# Altium schematic sheet Power.SchDoc
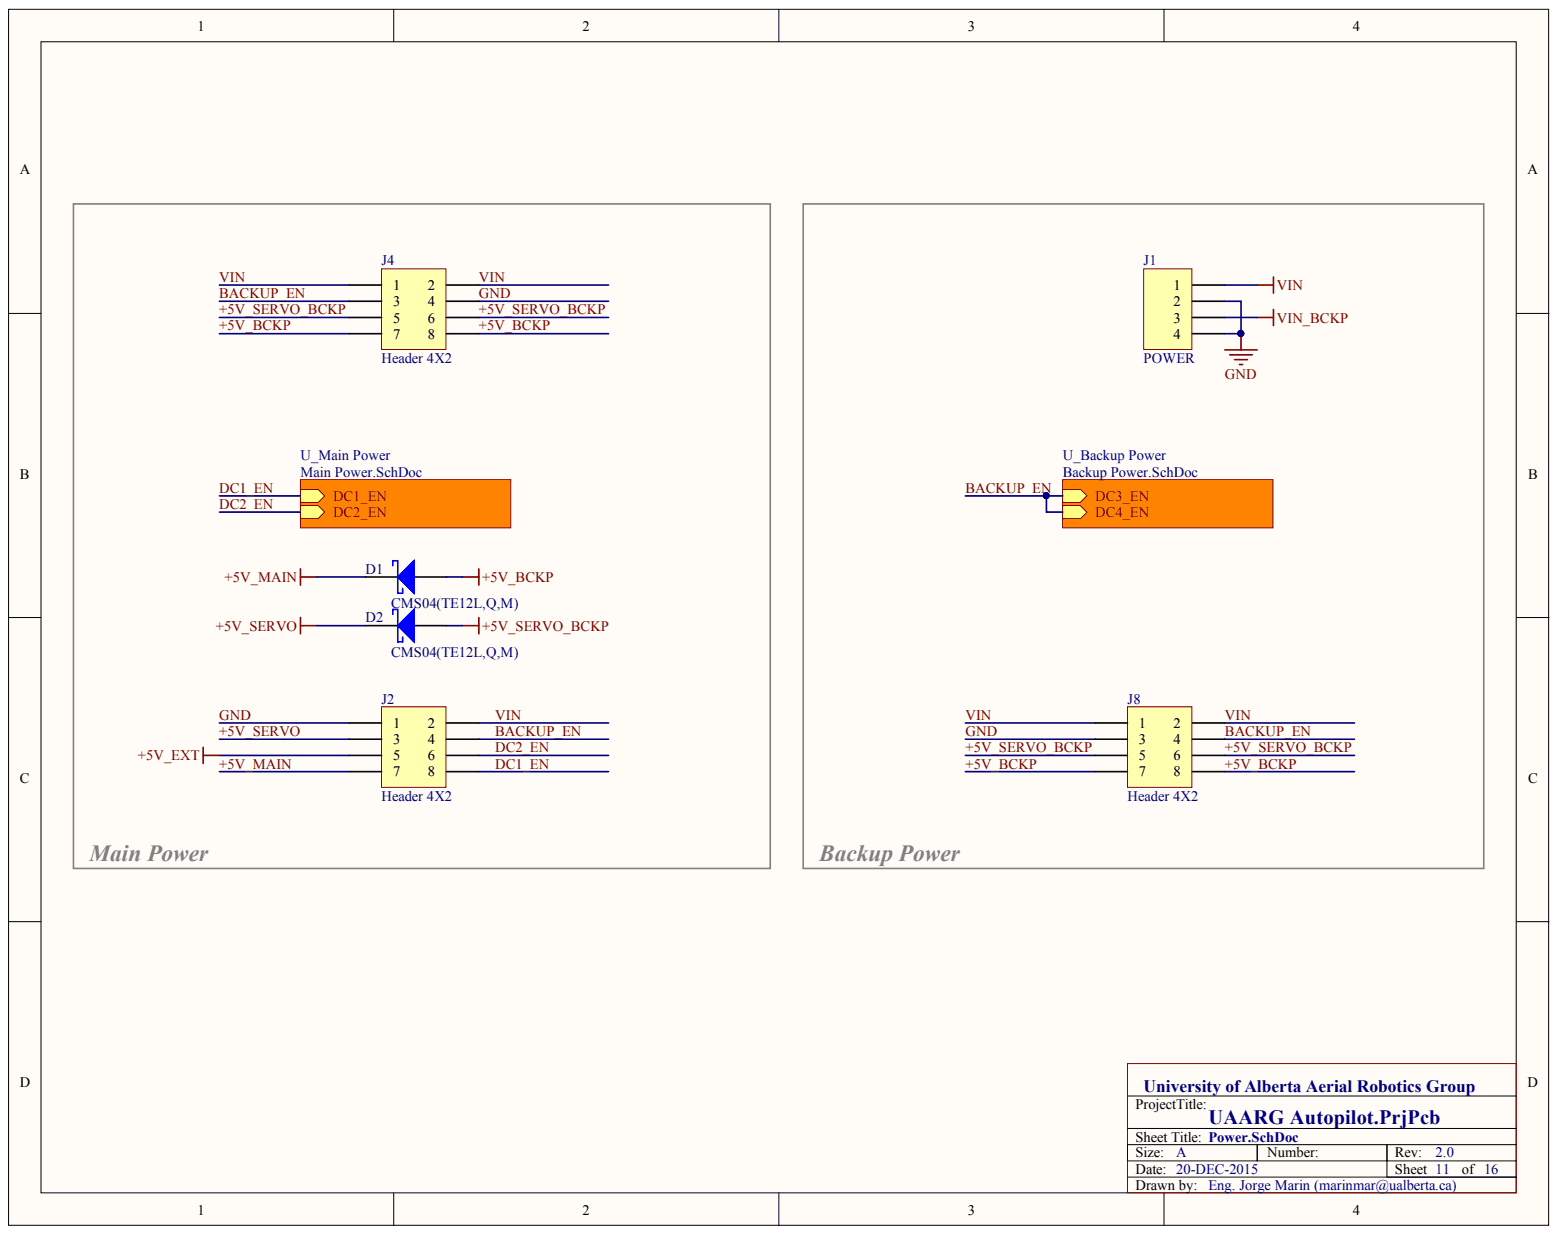
[diagram p0: Power.SchDoc]

## Parts
D1  Manufacturer Part Number: CMS04(TE12L,Q,M)  [SOD-128]  (hidden comment: SCHOTTKY DIODE)
D2  Manufacturer Part Number: CMS04(TE12L,Q,M)  [SOD-128]  (hidden comment: SCHOTTKY DIODE)
J1  POWER  [1X04_v2]  (no BOM)
J2  Header 4X2  [2X04]  (no BOM)
J4  Header 4X2  [2X04]  (no BOM)
J8  Header 4X2  [2X04]  (no BOM)

## Nets
+5V_EXT: J2.5
VIN_BCKP: J1.3
+5V_BCKP: D1.1 J4.7 J4.8 J8.7 J8.8
+5V_MAIN: D1.2 J2.7
+5V_SERVO: D2.2 J2.3
+5V_SERVO_BCKP: D2.1 J4.5 J4.6 J8.5 J8.6
BACKUP_EN: J2.4 J4.3 J8.4
DC1_EN: J2.8
DC2_EN: J2.6
GND: J1.2 J1.4 J2.1 J4.4 J8.3
VIN: J1.1 J2.2 J4.1 J4.2 J8.1 J8.2

## Library data of the parts
D1 D2 = SCHOTTKY DIODE: Typical Schottky Diode; Manufacturer: Toshiba Semiconductor and Storage; Capacitance @ Vr, F: 330pF @ 10V, 1MHz; Current - Average Rectified (Io): 5A; Current - Reverse Leakage @ Vr: 8mA @ 30V; Speed: Fast Recovery =< 500ns, > 200mA (Io); Voltage - DC Reverse (Vr) (Max): 30V; Voltage - Forward (Vf) (Max) @ If: 370mV @ 5A; Component Kind: Standard; ComponentLink1Description: Datasheet; ComponentLink1URL: http://toshiba.semicon-storage.com/info/docget.jsp?did=3133&prodName=CMS04; Supplier 1: Digi-Key; Supplier Part Number 1: CMS04(TE12LQM)CT-ND; Library Name: UAARG Autopilot.SchLib
J1 = Header 4: Header, 4-Pin; Library Name: Miscellaneous Connectors.IntLib; Signal Integrity: Connector
J2 J4 J8 = Header 4X2: Header, 4-Pin, Dual row; Library Name: Miscellaneous Connectors.IntLib; Signal Integrity: Connector
